# Altium schematic sheet KoruzaShieldV3-IO.SchDoc
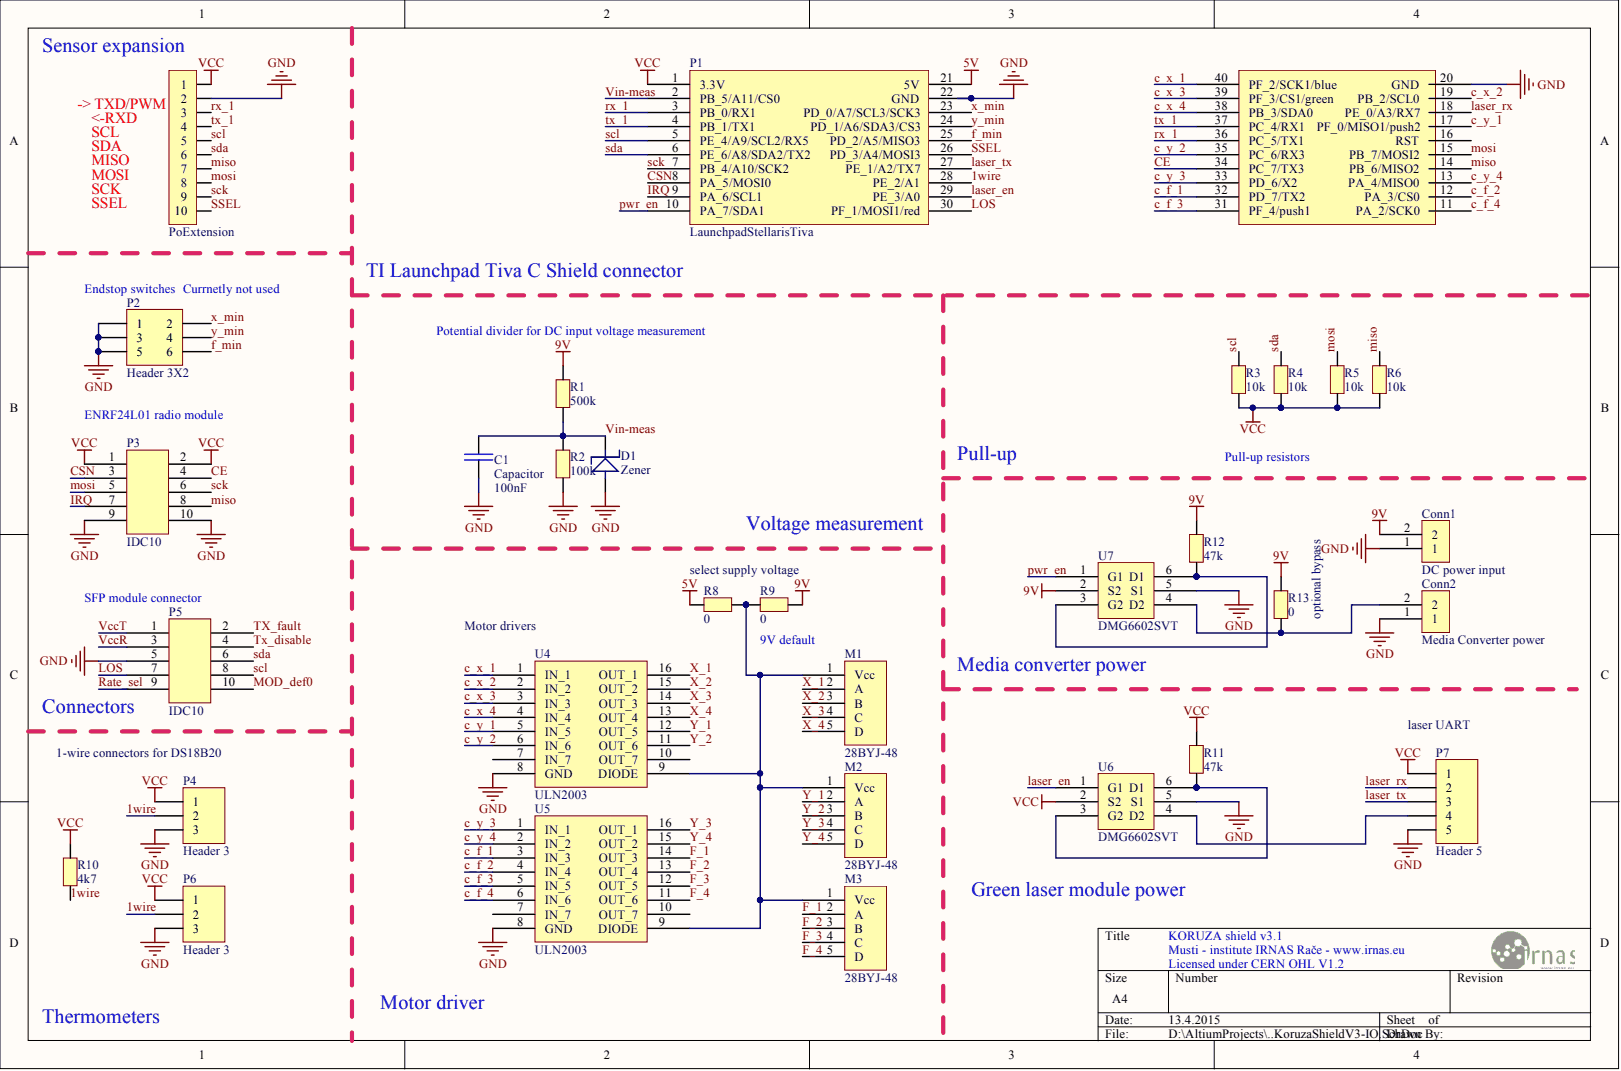
[diagram p0: KoruzaShieldV3-IO.SchDoc]

## Parts
C1  Capacitor  value 100nF  [0603]
Conn1  DC power input  [MKDSN 2,5/ 2-5,08 and powercon]
Conn2  Media Converter power  [MKDSN 2,5/ 2-5,08 and powercon]
D1  Zener  [DIOMELF3517N]
M1  28BYJ-48  [XHP-5]
M2  28BYJ-48  [XHP-5]
M3  28BYJ-48  [XHP-5]
P1  LaunchpadStellarisTiva  [LaunchpadDual]
P2  Header 3X2  [HDR2X3]
P3  IDC10  [IDC10]
P4  Header 3  [HDR1X3]
P5  IDC10  [IDC10]
P6  Header 3  [HDR1X3]
P7  Header 5  [HDR1X5]
PoExtension  (no value)  [8-188275-0]
R1  500k  [RESC2012X06N]
R2  100k  [RESC2012X06N]
R3  10k  [RESC2012X06N]
R4  10k  [RESC2012X06N]
R5  10k  [RESC2012X06N]
R6  10k  [RESC2012X06N]
R8  0  [RESC2012X06N]
R9  0  [RESC2012X06N]
R10  4k7  [RESC2012X06N]
R11  47k  [RESC2012X06N]
R12  47k  [RESC2012X06N]
R13  0  [RESC2012X06N]
U4  ULN2003  [SO-16 narrow]
U5  ULN2003  [SO-16 narrow]
U6  DMG6602SVT  [SOT95P245X100-6N]
U7  DMG6602SVT  [SOT95P245X100-6N]

## Nets
5V: P1.21 R8.2
9V: Conn1.2 R1.2 R9.1 R12.1 R13.2 U7.2(S2)
GND: C1.2 Conn1.1 Conn2.1 D1.2 P1.20(GND) P1.22 P2.1 P2.3 P2.5 P3.9 P3.10 P4.3 P5.5 P6.3 P7.5 PoExtension.2 R2.1 U4.8(GND) U5.8(GND) U6.5(S1) U7.5(S1)
VCC: P1.1(3.3V) P3.1 P3.2 P4.1 P6.1 P7.1 PoExtension.1 R3.1 R4.1 R5.1 R6.1 R10.1 R11.1 U6.2(S2)
1WIRE: P1.28(1wire) P4.2 P6.2 R10.2
C_F_1: P1.32 U5.3(IN_3)
C_F_2: P1.12(PA_3/CS0) U5.4(IN_4)
C_F_3: P1.31 U5.5(IN_5)
C_F_4: P1.11(PA_2/SCK0) U5.6(IN_6)
C_X_1: P1.40 U4.1(IN_1)
C_X_2: P1.19(PB_2/SCL0) U4.2(IN_2)
C_X_3: P1.39 U4.3(IN_3)
C_X_4: P1.38(c_x_4) U4.4(IN_4)
C_Y_1: P1.17(PF_0/MISO1/push2) U4.5(IN_5)
C_Y_2: P1.35 U4.6(IN_6)
C_Y_3: P1.33(c_y_3) U5.1(IN_1)
C_Y_4: P1.13(PA_4/MISO0) U5.2(IN_2)
CE: P1.34 P3.4
CSN: P1.8(PA_5/MOSI0) P3.3
F_1: M3.2(A) U5.14(OUT_3)
F_2: M3.3(B) U5.13(OUT_4)
F_3: M3.4(C) U5.12(OUT_5)
F_4: M3.5(D) U5.11(OUT_6)
F_MIN: P1.25 P2.6
IRQ: P1.9(PA_6/SCL1) P3.7
LASER_EN: P1.29 U6.1(G1)
LASER_RX: P1.18(PE_0/A3/RX7) P7.2
LASER_TX: P1.27 P7.3
LOS: P1.30 P5.7
MISO: P1.14(PB_6/MISO2) P3.8 PoExtension.7 R6.2
MOD_DEF0: P5.10
MOSI: P1.15(PB_7/MOSI2) P3.5 PoExtension.8 R5.2
PWR_EN: P1.10(PA_7/SDA1) U7.1(G1)
RATE_SEL: P5.9
RX_1: P1.3(PB_0/RX1) P1.36 PoExtension.3
SCK: P1.7(PB_4/A10/SCK2) P3.6 PoExtension.9
SCL: P1.5(PE_4/A9/SCL2/RX5) P5.8 PoExtension.5 R3.2
SDA: P1.6(PE_6/A8/SDA2/TX2) P5.6 PoExtension.6 R4.2
SSEL: P1.26 PoExtension.10
TX_1: P1.4(PB_1/TX1) P1.37 PoExtension.4
TX_DISABLE: P5.4
TX_FAULT: P5.2
VCCR: P5.3
VCCT: P5.1
VIN-MEAS: C1.1 D1.1 P1.2(PB_5/A11/CS0) R1.1 R2.2
X_1: M1.2(A) U4.16(OUT_1)
X_2: M1.3(B) U4.15(OUT_2)
X_3: M1.4(C) U4.14(OUT_3)
X_4: M1.5(D) U4.13(OUT_4)
X_MIN: P1.23(x_min) P2.2
Y_1: M2.2(A) U4.12(OUT_5)
Y_2: M2.3(B) U4.11(OUT_6)
Y_3: M2.4(C) U5.16(OUT_1)
Y_4: M2.5(D) U5.15(OUT_2)
Y_MIN: P1.24 P2.4
NetConn2_2: Conn2.2 R13.1 U7.4(D2)
NetM1_1: M1.1(Vcc) M2.1(Vcc) M3.1(Vcc) R8.1 R9.2 U4.9(DIODE) U5.9(DIODE)
NetP7_4: P7.4 U6.4(D2)
NetR11_2: R11.2 U6.3(G2) U6.6(D1)
NetR12_2: R12.2 U7.3(G2) U7.6(D1)

Not connected: P1 16(RST); U4 7(IN_7) 10(OUT_7); U5 7(IN_7) 10(OUT_7)

## Library data of the parts
C1 = Capacitor: General capacitor; Component Kind: Standard; Library Name: GeneralComponents.SchLib
Conn1 Conn2 = PowerScrewTerminal: Component Kind: Standard; Library Name: GeneralComponents.SchLib
M1-M3 = 28BYJ-48: Stepper motor; Component Kind: Standard; Library Name: GeneralComponents.SchLib
P1 = LaunchpadStellarisTiva: Stellaris=Tiva; Component Kind: Standard; Library Name: GeneralComponents.SchLib
P2 = Header 3X2: Header, 3-Pin, Dual row; Component Kind: Standard; LatestRevisionDate: 17-Jul-2002; LatestRevisionNote: Re-released for DXP Platform.; Library Name: Miscellaneous Connectors.IntLib; Signal Integrity: Connector; Publisher: Altium Limited
P3 P5 = IDC10: IDC 10pin; Component Kind: Standard; Library Name: GeneralComponents.SchLib
P4 P6 = Header 3: Header, 3-Pin; Component Kind: Standard; LatestRevisionDate: 17-Jul-2002; LatestRevisionNote: Re-released for DXP Platform.; Library Name: Miscellaneous Connectors.IntLib; Signal Integrity: Connector; Publisher: Altium Limited
P7 = Header 5: Header, 5-Pin; Component Kind: Standard; LatestRevisionDate: 17-Jul-2002; LatestRevisionNote: Re-released for DXP Platform.; Library Name: Miscellaneous Connectors.IntLib; Signal Integrity: Connector; Publisher: Altium Limited
PoExtension = 1-215079-0: Micro-Match female PCB connector 10 Positions THT; Component Kind: Standard; Library Name: BorisMicroMatch.SchLib
R1-R6 R8-R13 = Resistor: Resistor 0805 smd; Component Kind: Standard; Library Name: GeneralComponents.SchLib
U4 U5 = ULN2003: Darlington array; Component Kind: Standard; Library Name: GeneralComponents.SchLib
U6 U7 = DMG6602SVT: N and P mosfet 30V 3A, 1 is N, 2 is P; Component Kind: Standard; Library Name: GeneralComponents.SchLib
D1 = Zener: Component Kind: Standard; Description: Zener; Library Name: GeneralComponents.SchLib
